# Altium schematic sheet PowerSupply.SchDoc(PowerSupply)
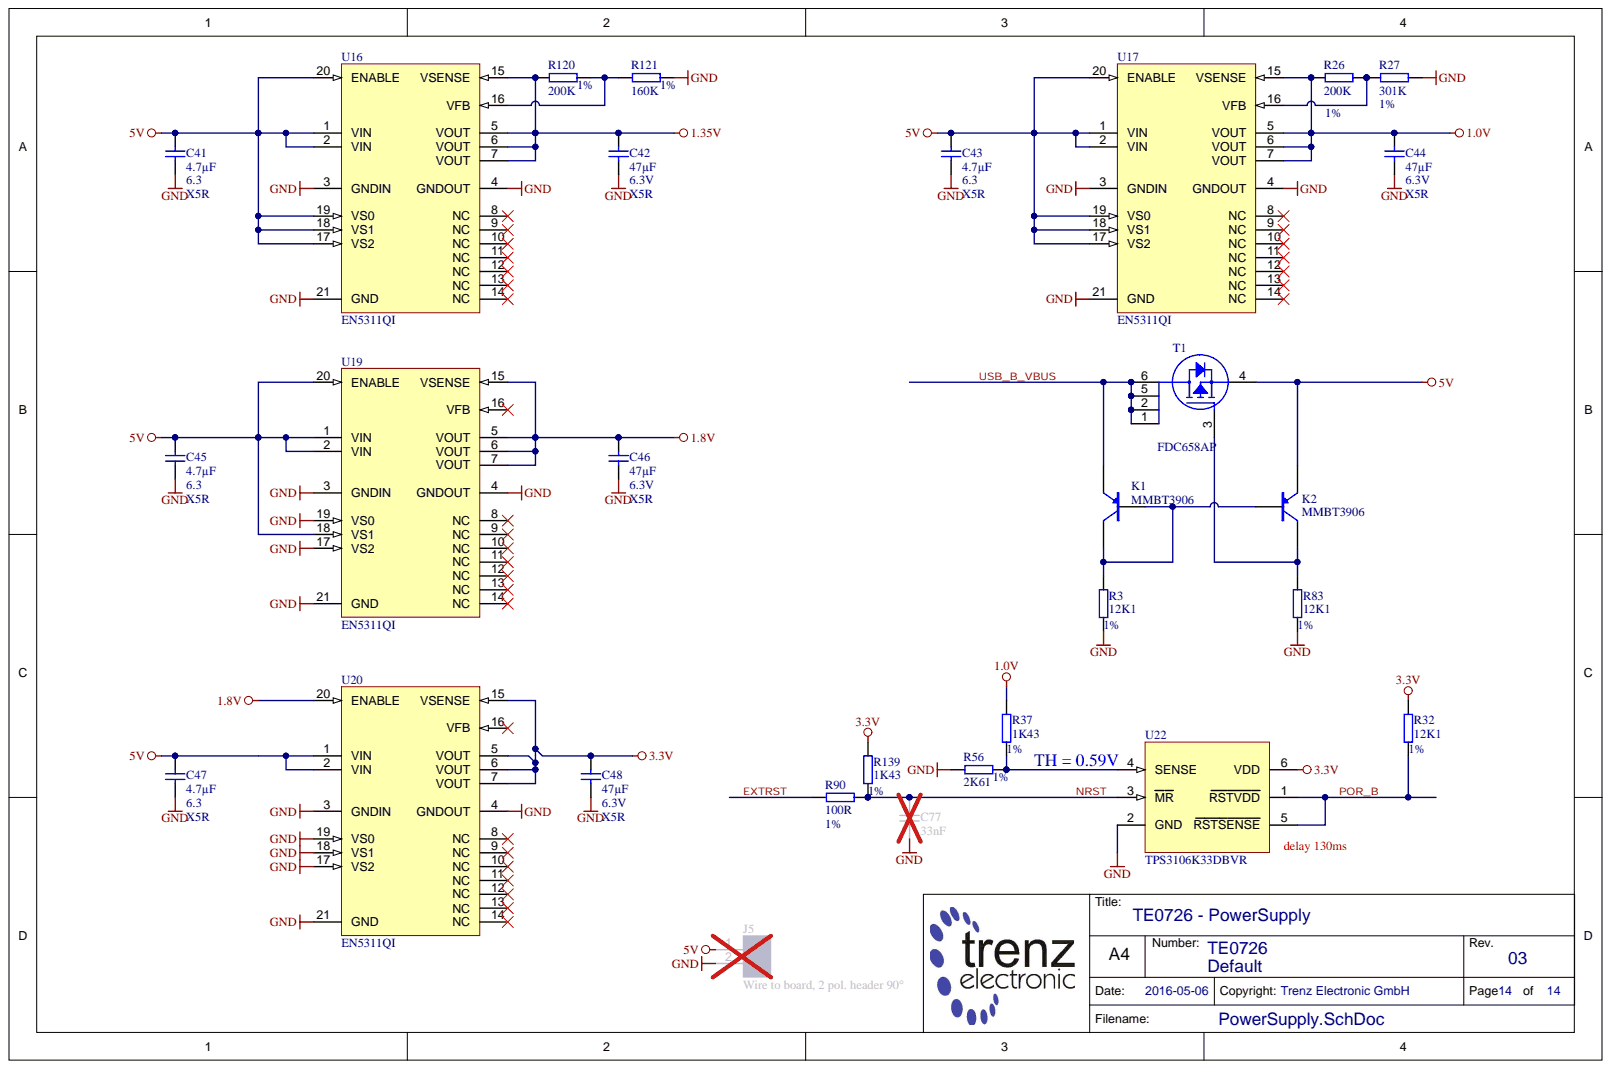
[diagram p0: PowerSupply.SchDoc]

## Parts
C41  4.7µF  Technology: X5R  Voltage: 6.3  [CAPC1005X60N]
C42  47µF  Technology: X5R  Voltage: 6.3V  [CAPC2012X135N]
C43  4.7µF  Technology: X5R  Voltage: 6.3  [CAPC1005X60N]
C44  47µF  Technology: X5R  Voltage: 6.3V  [CAPC2012X135N]
C45  4.7µF  Technology: X5R  Voltage: 6.3  [CAPC1005X60N]
C46  47µF  Technology: X5R  Voltage: 6.3V  [CAPC2012X135N]
C47  4.7µF  Technology: X5R  Voltage: 6.3  [CAPC1005X60N]
C48  47µF  Technology: X5R  Voltage: 6.3V  [CAPC2012X135N]
C77  33nF  [CAPC1005X55N]
J5  Wire to board, 2 pol. header 90°  [640457-2]
K1  MMBT3906  [SOT95P280X145-3N]
K2  MMBT3906  [SOT95P280X145-3N]
R3  12K1  Tolerance: 1%  [RESC1005X40N]
R26  200K  Tolerance: 1%  [RESC1005X40N]
R27  301K  Tolerance: 1%  [RESC1005X40N]
R32  12K1  Tolerance: 1%  [RESC1005X40N]
R37  1K43  Tolerance: 1%  [RESC1005X40N]
R56  2K61  Tolerance: 1%  [RESC1005X40N]
R83  12K1  Tolerance: 1%  [RESC1005X40N]
R90  100R  Tolerance: 1%  [RESC1005X40N]
R120  200K  Tolerance: 1%  [RESC1005X40N]
R121  160K  Tolerance: 1%  [RESC1005X40N]
R139  1K43  Tolerance: 1%  [RESC1005X40N]
T1  FDC658AP  [SOT95P280X110-6N]
U16  EN5311QI  [EN5311QI]
U17  EN5311QI  [EN5311QI]
U19  EN5311QI  [EN5311QI]
U20  EN5311QI  [EN5311QI]
U22  TPS3106K33DBVR  [SOT95P280X145-6N]

## Nets
1.0V: C44.1 R26.1 R37.1 U17.5(VOUT) U17.6(VOUT) U17.7(VOUT) U17.15(VSENSE)
1.8V: C46.1 U19.5(VOUT) U19.6(VOUT) U19.7(VOUT) U19.15(VSENSE) U20.20(ENABLE)
1.35V: C42.1 R120.1 U16.5(VOUT) U16.6(VOUT) U16.7(VOUT) U16.15(VSENSE)
3.3V: C48.1 R32.2 R139.1 U20.5(VOUT) U20.6(VOUT) U20.7(VOUT) U20.15(VSENSE) U22.6(VDD)
5V: C41.1 C43.1 C45.1 C47.1 J5.1 K2.2 T1.4 U16.1(VIN) U16.2(VIN) U16.17(VS2) U16.18(VS1) U16.19(VS0) U16.20(ENABLE) U17.1(VIN) U17.2(VIN) U17.17(VS2) U17.18(VS1) U17.19(VS0) U17.20(ENABLE) U19.1(VIN) U19.2(VIN) U19.18(VS1) U19.20(ENABLE) U20.1(VIN) U20.2(VIN)
GND: C41.2 C42.2 C43.2 C44.2 C45.2 C46.2 C47.2 C48.2 C77.1 J5.2 R3.2 R27.2 R56.1 R83.2 R121.2 U16.3(GNDIN) U16.4(GNDOUT) U16.21(GND) U17.3(GNDIN) U17.4(GNDOUT) U17.21(GND) U19.3(GNDIN) U19.4(GNDOUT) U19.17(VS2) U19.19(VS0) U19.21(GND) U20.3(GNDIN) U20.4(GNDOUT) U20.17(VS2) U20.18(VS1) U20.19(VS0) U20.21(GND) U22.2(GND)
EXTRST: R90.1
NRST: C77.2 R90.2 R139.2 U22.3(MR)
POR_B: R32.1 U22.1(RSTVDD) U22.5(RSTSENSE)
USB_B_VBUS: K1.2 T1.1 T1.2 T1.5 T1.6
NetK1_1: K1.1 K1.3 K2.1 R3.1
NetK2_3: K2.3 R83.1 T1.3
NetR26_2: R26.2 R27.1 U17.16(VFB)
NetR37_2: R37.2 R56.2 U22.4(SENSE)
NetR120_2: R120.2 R121.1 U16.16(VFB)

Not connected: U16 8(NC) 9(NC) 10(NC) 11(NC) 12(NC) 13(NC) 14(NC); U17 8(NC) 9(NC) 10(NC) 11(NC) 12(NC) 13(NC) 14(NC); U19 8(NC) 9(NC) 10(NC) 11(NC) 12(NC) 13(NC) 14(NC) 16(VFB); U20 8(NC) 9(NC) 10(NC) 11(NC) 12(NC) 13(NC) 14(NC) 16(VFB)

## Library data of the parts
C41 C43 C45 C47 = 24719: KON 4.7µF 6.3V X5R SMD 20%; Database Table Name: C_1005_0402; Mixed: SMT; Preferred: Yes; Value: 4.7u; AN: 24719; Case: 1005 (0402); Component Kind: Standard; Library Name: TE.DbLib; Tolerance: 20%
C42 C44 C46 C48 = 24718: KON 47µF 6,3V  X5R SMD 20%; Database Table Name: C_2012_0805; Mixed: SMT; Preferred: Yes; Value: 47u; AN: 24718; Case: 2012 (0805); Component Kind: Standard; Library Name: TE.DbLib; Tolerance: 20%
C77 = 23916: KON 33nF 25V X7R SMD; Database Table Name: C_1005_0402; Mixed: SMT; Preferred: Yes; Value: 33n; AN: 23916; Case: 1005 (0402); Component Kind: Standard; Library Name: TE.DbLib; Technology: X7R; Voltage: 25V
J5 = 27285: Database Table Name: CON_HEADER; Mixed: THT; AN: 27285; Component Kind: Standard; Description: Wire to board, 2 pol. header 90°; H-ART: 640457-2; Library Name: TE.DbLib; HelpURL: B:\AD\adlib\datasheet\640457-2
K1 K2 = 26077: Database Table Name: TRANSISTOR; Mixed: SMT; Preferred: Yes; AN: 26077; Case: SOT23-3; Component Kind: Standard; Description: MMBT3906; H-ART: MMBT3906; Library Name: TE.DbLib; Trenz-Stock: Yes; HelpURL: B:\AD\adlib\datasheet\3906
R3 R32 R83 = 22849: WID SMD 12K1 1% 0,063W; Database Table Name: R_1005_0402; Mixed: SMT; Preferred: Yes; Value: 12.1K; AN: 22849; Case: 1005 (0402); Component Kind: Standard; Library Name: TE.DbLib; Technology: TK100
R26 R120 = 23846: WID SMD 200K 1% 0,063W; Database Table Name: R_1005_0402; Mixed: SMT; Preferred: Yes; AN: 23846; Case: 1005 (0402); Component Kind: Standard; Library Name: TE.DbLib; Technology: TK100; Value: 200K
R27 = 26224: WID SMD 301K 1% 0,063W; Database Table Name: R_1005_0402; Mixed: SMT; AN: 26224; Case: 1005 (0402); Component Kind: Standard; Library Name: TE.DbLib; Technology: TK100; Value: 301k
R37 R139 = 23473: WID SMD 1K43 1% 0,063W; Database Table Name: R_1005_0402; Mixed: SMT; Value: 1.43K; AN: 23473; Case: 1005 (0402); Component Kind: Standard; Library Name: TE.DbLib; Technology: TK100
R56 = 23730: WID SMD 2K61 1% 0,063W; Database Table Name: R_1005_0402; Mixed: SMT; Preferred: Yes; Value: 2.61K; AN: 23730; Case: 1005 (0402); Component Kind: Standard; Library Name: TE.DbLib; Technology: TK100
R90 = 22507: WID SMD 100R 1% 0,063W; Database Table Name: R_1005_0402; Mixed: SMT; Preferred: Yes; Value: 100; AN: 22507; Case: 1005 (0402); Component Kind: Standard; Library Name: TE.DbLib; Technology: TK100
R121 = 22934: WID SMD 160K 1% 0,063W; Database Table Name: R_1005_0402; Mixed: SMT; Preferred: Yes; AN: 22934; Case: 1005 (0402); Component Kind: Standard; Library Name: TE.DbLib; Technology: TK100; Value: 160K
T1 = 27166: Database Table Name: TRANSISTOR; Mixed: SMT; Trenz-Stock: Yes; AN: 27166; Case: SOT23-6; Component Kind: Standard; Description: FDC658AP; H-ART: FDC658AP; Library Name: TE.DbLib; HelpURL: B:\AD\adlib\datasheet\FDC658AP
U16 U17 U19 U20 = 25492: Database Table Name: DCDC; Mixed: SMT; Preferred: Yes; AN: 25492; Case: QFN20; Component Kind: Standard; Description: EN5311QI; H-ART: EN5311QI; Library Name: TE.DbLib; Trenz-Stock: Yes; HelpURL: B:\AD\adlib\datasheet\EN5311QI
U22 = 26439: Database Table Name: SUPERVISOR; Mixed: SMT; AN: 26439; Case: SOT23-6; Component Kind: Standard; Description: TPS3106K33DBVR; H-ART: TPS3106K33DBVR; Library Name: TE.DbLib; HelpURL: B:\ad\adlib\datasheet\TPS3106
